# Altium schematic sheet POWER_CONTROLLER.SchDoc
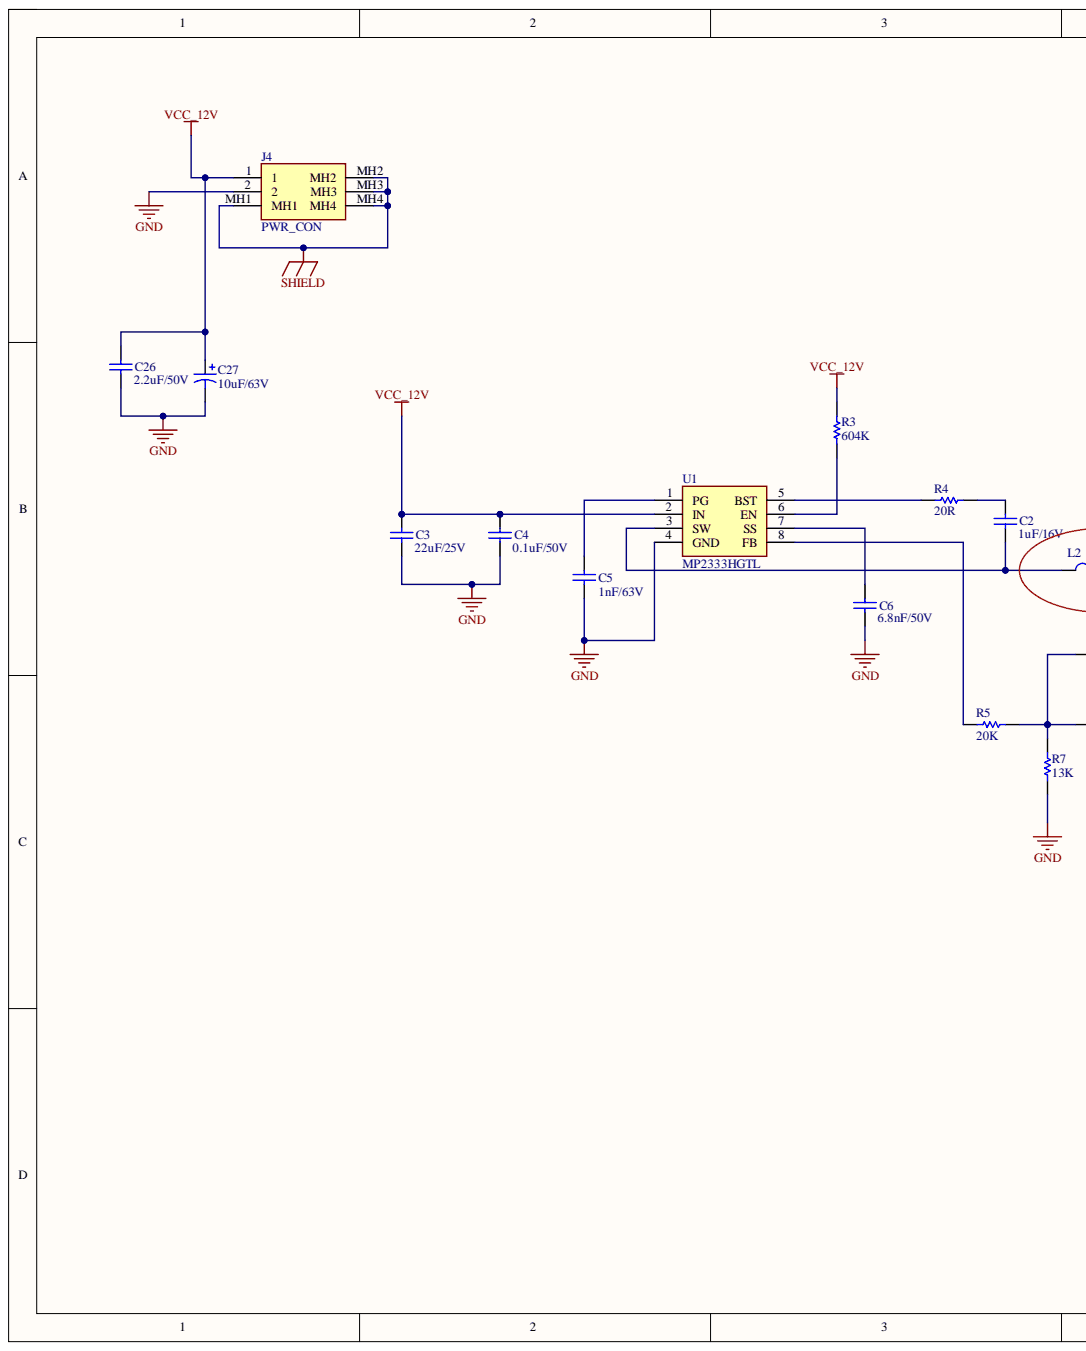
[diagram p0: POWER_CONTROLLER.SchDoc, left]
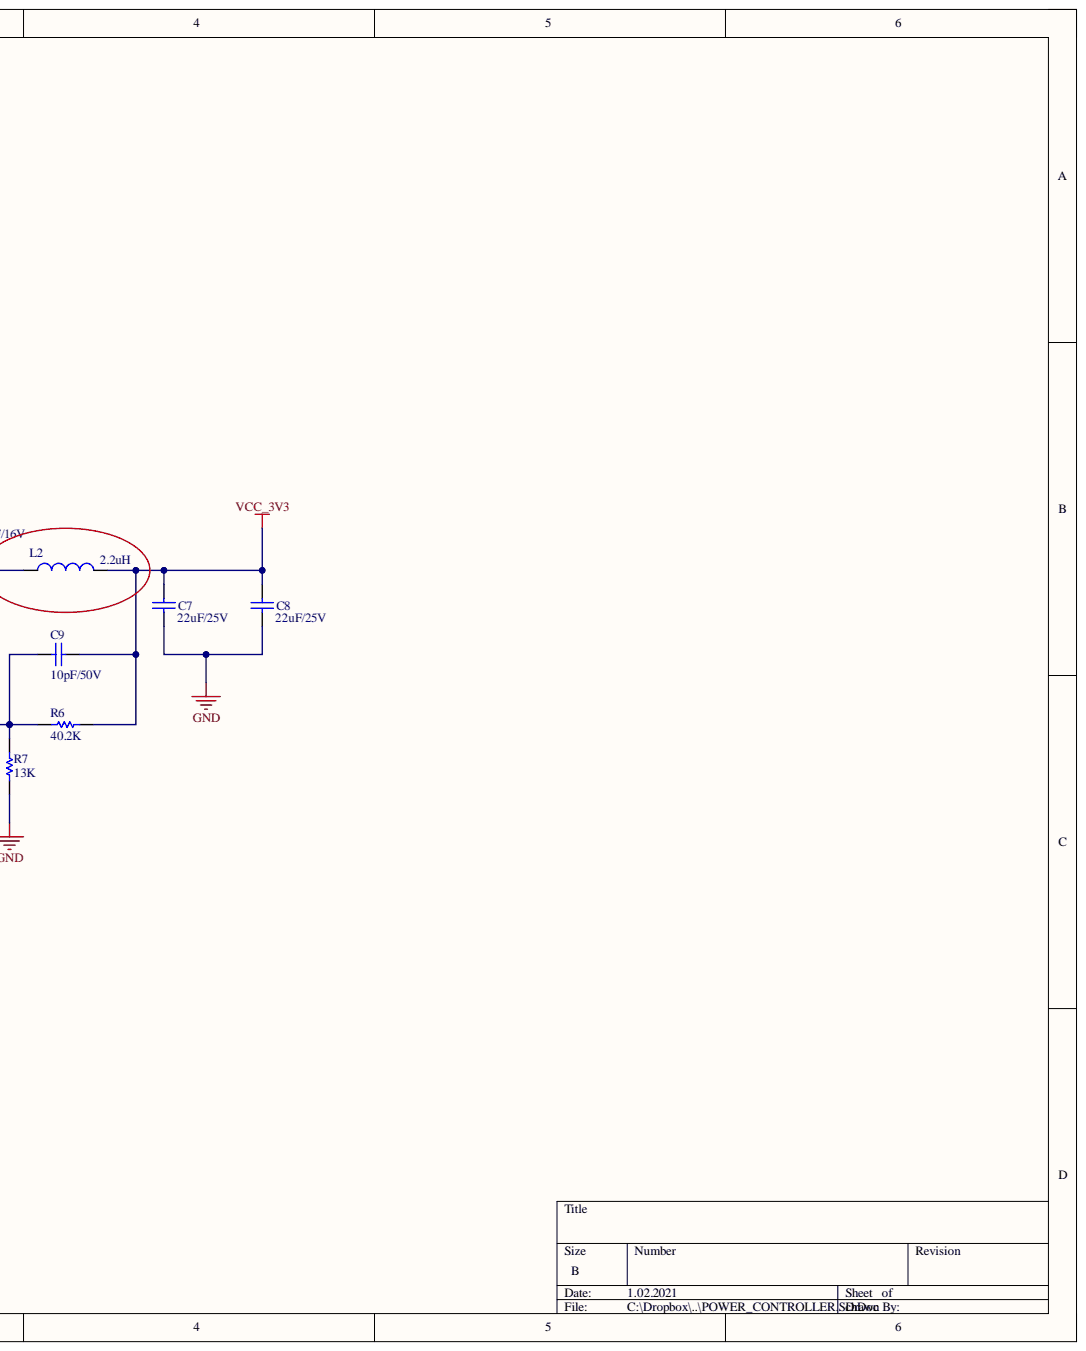
[diagram p1: POWER_CONTROLLER.SchDoc, right]

## Parts
C2  1uF/16V
C3  22uF/25V
C4  0.1uF/50V  [RAD-0.3]
C5  1nF/63V
C6  6.8nF/50V
C7  22uF/25V
C8  22uF/25V
C9  10pF/50V
C26  2.2uF/50V  [0603C]
C27  10uF/63V  [EEE-FN1J100L]
J4  PWR_CON  [PJ082BH]
L2  2.2uH
R3  604K
R4  20R
R5  20K  [0603R]
R6  40.2K  [0603R]
R7  13K  [0603R]
U1  MP2333HGTL  [SOTFL50P160X60-8N]

## Nets
GND: C3.1 C4.1 C5.1 C6.1 C7.1 C8.1 C26.1 C27.2 J4.2 R7.2 U1.4(GND)
SHIELD: J4.MH1 J4.MH2 J4.MH3 J4.MH4
VCC_3V3: C7.2 C8.2 C9.1 L2.2 R6.2
VCC_12V: C3.2 C4.2 C26.2 C27.1 J4.1 R3.2 U1.2(IN)
NetC2_1: C2.1 L2.1 U1.3(SW)
NetC2_2: C2.2 R4.2
NetC5_2: C5.2 U1.1(PG)
NetC6_2: C6.2 U1.7(SS)
NetC9_2: C9.2 R5.1 R6.1 R7.1
NetR3_1: R3.1 U1.6(EN)
NetR4_1: R4.1 U1.5(BST)
NetR5_2: R5.2 U1.8(FB)

## Library data of the parts
C2 = Cap: Multilayer Ceramic Capacitors MLCC - SMD/SMT 16volts 1uF X7R 10%; Mfr: KEMET; Mfr Part No: C0603C105K4RAC7411; Component Kind: Standard; Library Name: Miscellaneous Devices.IntLib; Package: 0603; Price: 0.101; Signal Integrity: Cap; Simulation: CAP; Stockiest: MOUSER; Stockiest Part No: 80-C0603C105K4RLR; Value: 1uF/16V
C3 C7 C8 = Cap: Multilayer Ceramic Capacitors MLCC - SMD/SMT 1210 25VDC 22uF 20% X7R AEC-Q200; Mfr: Taiyo Yuden; Mfr Part No: TMK325B7226MMHP; Component Kind: Standard; Library Name: Miscellaneous Devices.IntLib; Package: 1210; Price: 0.807; Signal Integrity: Cap; Simulation: CAP; Stockiest: MOUSER; Stockiest Part No: 963-TMK325B7226MMHP; Value: 22uF/25V
C4 = Cap: Multilayer Ceramic Capacitors MLCC - SMD/SMT 50V 0.1uF 0603 X7R 20%; Mfr: KEMET; Mfr Part No: C0603C104M5RACTU; Component Kind: Standard; Library Name: Miscellaneous Devices.IntLib; Package: 0603; Price: 0.101; Signal Integrity: Cap; Simulation: CAP; Stockiest: MOUSER; Stockiest Part No: 80-C0603C104M5R; Value: 0.1uF/50V
C5 = Cap: Multilayer Ceramic Capacitors MLCC - SMD/SMT 63V 1000pF 0603 X7R 10% AEC-Q200; Mfr: KEMET; Mfr Part No: 80-C0603C102KMREAUTO; Component Kind: Standard; Library Name: Miscellaneous Devices.IntLib; Package: 0603; Price: 0.201; Signal Integrity: Cap; Simulation: CAP; Stockiest: MOUSER; Stockiest Part No: C0603C102KMRECAUTO; Value: 1nF/63V
C6 = Cap: Multilayer Ceramic Capacitors MLCC - SMD/SMT 0603 50VDC 6.8nF 5% C0G AEC-Q200; Mfr: TDK; Mfr Part No: CGA3E2C0G1H682J080AE; Component Kind: Standard; Library Name: Miscellaneous Devices.IntLib; Package: 0603; Price: 0.363; Signal Integrity: Cap; Simulation: CAP; Stockiest: MOUSER; Stockiest Part No: 810-CGA3E2C0G1H682J8; Value: 6.8nF/50V
C9 = Cap: Multilayer Ceramic Capacitors MLCC - SMD/SMT 50V 10pF 0603 C0G 5% AEC-Q200; Mfr: KEMET; Mfr Part No: C0603C100J5GACAUTO; Component Kind: Standard; Library Name: Miscellaneous Devices.IntLib; Package: 0603; Price: 0.192; Signal Integrity: Cap; Simulation: CAP; Stockiest: MOUSER; Stockiest Part No: 80-C0603C100J5GAUTO; Value: 10pF/50V
C26 = Cap: Multilayer Ceramic Capacitors MLCC - SMD/SMT 0603 2.2uF 20% 50VDC X5R; Mfr: Murata Electronics; Mfr Part No: GRM188R61H225ME11J; Component Kind: Standard; Library Name: Miscellaneous Devices.IntLib; Package: 0603; Price: 0.333; Signal Integrity: Cap; Simulation: CAP; Stockiest: MOUSER; Stockiest Part No: 81-GRM188R61H225ME1J; Value: 2.2uF/50V
C27 = Cap Pol1: Polarized Capacitor (Radial); Mfr: Panasonic; Component Kind: Standard; Library Name: Miscellaneous Devices.IntLib; Mfr Part No: EEE-FN1J100L; Package: SMD; Price: 0.484; Signal Integrity: Cap Pol; Simulation: CAP; Stockiest: MOUSER; Stockiest Part No: 667-EEE-FN1J100L; Value: 10uF/63V
J4 = PJ-082BH: DC Power Connectors 2.5mm x 5.5mm horz; Mfr: CUI Devices; Component Kind: Standard; Mfr Part No: PJ-082BH; Price: 1.70; Stockiest: MOUSER; Stockiest Part No: 490-PJ-082BH
L2 = INDUCTOR: Fixed Inductors 2.2uH 20% High Temp AEC-Q200; Mfr: Vishay / Dale; Mfr Part No: IHLP1616BZER2R2M5A; Component Kind: Standard; Library Name: de20.SchLib; Price: 1.36; Stockiest: MOUSER; Stockiest Part No: 70-IHLP1616BZR2R2M5A; Value: 2.2uH
R3 = Res1: Thick Film Resistors - SMD 0603 1% 604Kohm Anti-Sulfur AEC-Q200; Mfr: Panasonic; Mfr Part No: ERJ-U03F6043V; Component Kind: Standard; Library Name: Miscellaneous Devices.IntLib; Package: 0603; Price: 0.221; Signal Integrity: Res; Simulation: RESISTOR; Stockiest: MOUSER; Stockiest Part No: 667-ERJ-U03F6043V; Value: 604K
R4 = Res1: Thick Film Resistors - SMD 0603 0.25W 1% 20ohm AEC-Q200; Mfr: Panasonic; Mfr Part No: ERJ-UP3F20R0V; Component Kind: Standard; Library Name: Miscellaneous Devices.IntLib; Package: 0603; Price: 0.262; Signal Integrity: Res; Simulation: RESISTOR; Stockiest: MOUSER; Stockiest Part No: 667-ERJ-UP3F20R0V; Value: 20R
R5 = Res1: Thick Film Resistors - SMD 20K OHM .1% 25PPM; Mfr: KOA Speer; Mfr Part No: RS73F1JTTD2002B; Component Kind: Standard; Library Name: Miscellaneous Devices.IntLib; Package: 0603; Price: 0.61; Signal Integrity: Res; Simulation: RESISTOR; Stockiest: MOUSER; Stockiest Part No: 660-RS73F1JTTD2002B; Value: 20K
R6 = Res1: Thick Film Resistors - SMD 0603 Anti-Surge Res. 0.1%, 40.2Koh; Mfr: Panasonic; Mfr Part No: ERJ-PB3B4022V; Component Kind: Standard; Library Name: Miscellaneous Devices.IntLib; Package: 0603; Price: 0.252; Signal Integrity: Res; Simulation: RESISTOR; Stockiest: MOUSER; Stockiest Part No: 667-ERJ-PB3B4022V; Value: 40.2K
R7 = Res1: Thin Film Resistors - SMD 13K ohm 0.1% 25 ppm; Mfr: KOA Speer; Mfr Part No: RN73R1JTTD1302B25; Component Kind: Standard; Library Name: Miscellaneous Devices.IntLib; Package: 0603; Price: 0.503; Signal Integrity: Res; Simulation: RESISTOR; Stockiest: MOUSER; Stockiest Part No: 660-RN73R1JTD1302B25; Value: 13K
U1 = MP2333HGTL: Switching Voltage Regulators High-Efficiency, 3A, 18V, 1200kHz, Synchronous, Step-Down Converter; Mfr: Monolithic Power Systems (MPS); Mfr Part No: MP2333HGTL-P; Value: 3.3V; Component Kind: Standard; Price: 1.89; Stockiest: MOUSER; Stockiest Part No: 946-MP2333HGTL-P
